# Altium schematic sheet shematic.SchDoc(shematic)
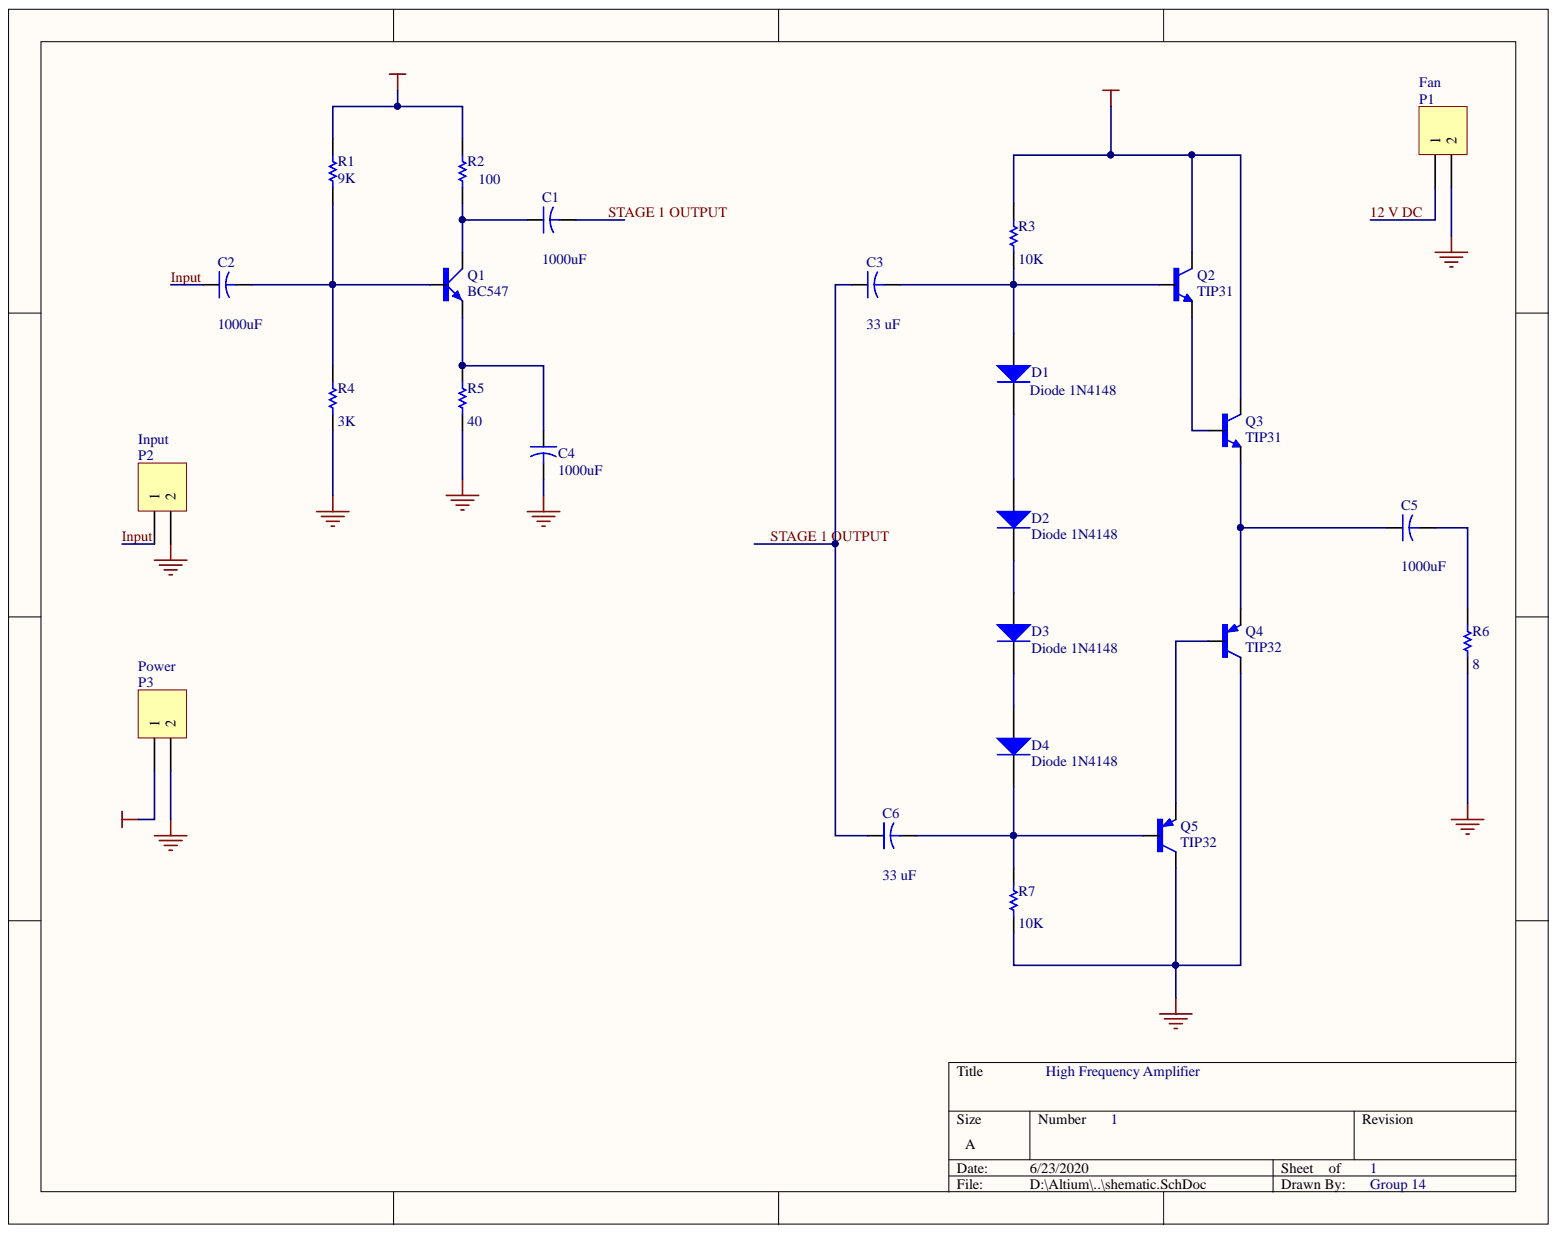
[diagram p0: shematic.SchDoc]

## Parts
C1  value 1000uF  [CAPR5-4X5]  (hidden comment: Cap2)
C2  value 1000uF  [CAPR5-4X5]  (hidden comment: Cap2)
C3  value 33 uF  [CAPR5-4X5]  (hidden comment: Cap2)
C4  value 1000uF  [CAPR5-4X5]  (hidden comment: Cap2)
C5  value 1000uF  [CAPR5-4X5]  (hidden comment: Cap2)
C6  value 33 uF  [CAPR5-4X5]  (hidden comment: Cap2)
D1  Diode 1N4148  [DO-35]
D2  Diode 1N4148  [DO-35]
D3  Diode 1N4148  [DO-35]
D4  Diode 1N4148  [DO-35]
P1  Fan  [HDR1X2]
P2  Input  [HDR1X2]
P3  Power  [HDR1X2H]
Q1  BC547  [TRANSISTOR-TO92-CBE]
Q2  TIP31  [TO220]
Q3  TIP31  [TO220]
Q4  TIP32  [TO220]
Q5  TIP32  [TO220]
R1  value 9K  [AXIAL-0.3]  (hidden comment: Res1)
R2  value 100  [AXIAL-0.3]  (hidden comment: Res1)
R3  value 10K  [AXIAL-0.3]  (hidden comment: Res1)
R4  value 3K  [AXIAL-0.3]  (hidden comment: Res1)
R5  value 40  [AXIAL-0.3]  (hidden comment: Res1)
R6  value 8  [AXIAL-0.3]  (hidden comment: Res1)
R7  value 10K  [AXIAL-0.3]  (hidden comment: Res1)

## Nets
GND: C4.2 P1.2 P2.2 P3.2 Q4.2 Q5.2 R4.1 R5.2 R6.1 R7.1
12 V DC: P1.1 P3.1 Q2.2 Q3.2 R1.1 R2.1 R3.2
Input: C2.1 P2.1
STAGE 1 OUTPUT: C1.2 C3.1 C6.1
NetC1_1: C1.1 Q1.C R2.2
NetC2_2: C2.2 Q1.B R1.2 R4.2
NetC3_2: C3.2 D1.1 Q2.1 R3.1
NetC4_1: C4.1 Q1.E R5.1
NetC5_1: C5.1 Q3.3 Q4.3
NetC5_2: C5.2 R6.2
NetC6_2: C6.2 D4.2 Q5.1 R7.2
NetD1_2: D1.2 D2.1
NetD2_2: D2.2 D3.1
NetD3_2: D3.2 D4.1
NetQ2_3: Q2.3 Q3.1
NetQ4_1: Q4.1 Q5.3

## Library data of the parts
C1-C6 = Cap2: Capacitor; Simulation: CAP; Signal Integrity: Cap; Published: 23-Sep-2002; PackageReference: CAPR5-4X5; PackageDescription: Capacitor; 2 Leads; Library Name: Miscellaneous Devices.IntLib; Component Kind: Standard; Publisher: Altium Limited
D1-D4 = Diode 1N4148: High Conductance Fast Diode; Simulation: 1N4148; Signal Integrity: 1N4148; Published: 8-Jun-2000; PackageReference: DO-35; PackageDescription: Diode, Axial; Body 3.8 x 1.9 mm (LxDia. max), Lead Dia. 0.53 mm (max); Library Name: Miscellaneous Devices.IntLib; LatestRevisionNote: Re-released for DXP Platform.; LatestRevisionDate: 17-Jul-2002; DatasheetVersion: 1997; ComponentLink2URL: http://www.fairchildsemi.com/ds/1N%2F1N4148.pdf; ComponentLink2Description: Datasheet; ComponentLink1URL: http://www.fairchildsemi.com/; ComponentLink1Description: Manufacturer Link; Component Kind: Standard; Code_JEDEC: DO-204-AH; Publisher: Altium Limited
P1 P2 = Header 2: Header, 2-Pin; Signal Integrity: Connector; Library Name: Miscellaneous Connectors.IntLib; LatestRevisionNote: Re-released for DXP Platform.; LatestRevisionDate: 17-Jul-2002; Component Kind: Standard; Publisher: Altium Limited
P3 = Header 2H: Header, 2-Pin, Right Angle; Signal Integrity: Connector; Library Name: Miscellaneous Connectors.IntLib; LatestRevisionNote: Re-released for DXP Platform.; LatestRevisionDate: 17-Jul-2002; Component Kind: Standard; Publisher: Altium Limited
Q1 = BC547: Bipolar _BJT_ Transistor NPN 45V 100mA 300MHz 500mW Through Hole TO-92-3; MF: ON Semiconductor; SnapEDA_Link: https://www.snapeda.com/parts/BC547/ON%20Semiconductor/view-part/608530/?ref=snap; Price: None; Package: TO-92 ON Semiconductor; MP: BC547; Library Name: BC547.IntLib; Component Kind: Standard; Availability: Unavailable
Q2 Q3 = TIP31: Bipolar _BJT_ Transistor NPN 40V 3A 3MHz 2W Through Hole TO-220AB; MF: ON Semiconductor; SnapEDA_Link: https://www.snapeda.com/parts/TIP31/ON%20Semiconductor/view-part/2746873/?ref=snap; Price: None; Package: TO-220 Texas Instruments; MP: TIP31; Library Name: TIP31.IntLib; Component Kind: Standard; Availability: Unavailable
Q4 Q5 = TIP32: Bipolar _BJT_ Transistor PNP 40V 3A 3MHz 2W Through Hole TO-220-3; MF: ON Semiconductor; SnapEDA_Link: https://www.snapeda.com/parts/TIP32/ON%20Semiconductor/view-part/4572277/?ref=snap; Price: None; Package: TO-220 ON Semiconductor; MP: TIP32; Library Name: TIP32.IntLib; Component Kind: Standard; Availability: Unavailable
R1-R7 = Res1: Resistor; Simulation: RESISTOR; Signal Integrity: Res; Published: 8-Jun-2000; PackageReference: AXIAL-0.3; PackageDescription: Axial Device, Thru-Hole; 2 Leads; 0.3 in Pin Spacing; Library Name: Miscellaneous Devices.IntLib; LatestRevisionNote: Re-released for DXP Platform.; LatestRevisionDate: 17-Jul-2002; Component Kind: Standard; Publisher: Altium Limited
